# Altium schematic sheet Power.SchDoc
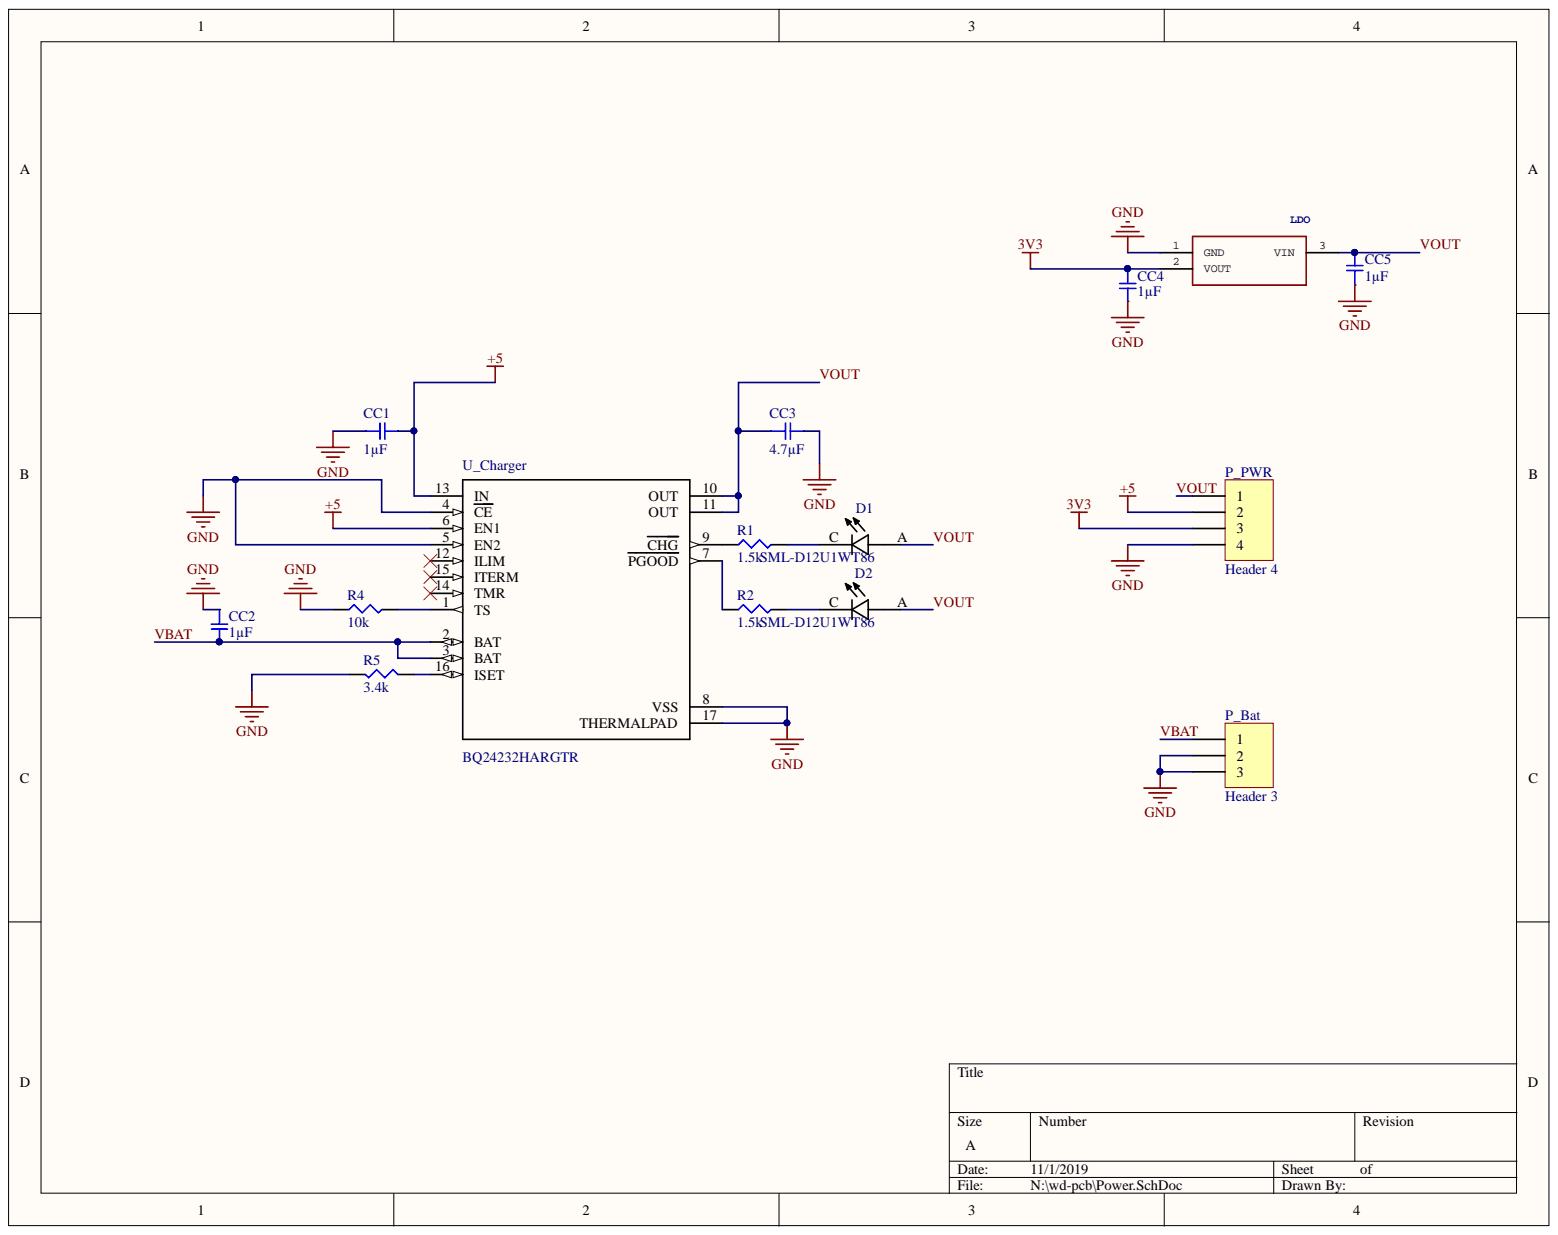
[diagram p0: Power.SchDoc]

## Parts
CC1  1µF  [SMD-0603C]
CC2  1µF  [SMD-0603C]
CC3  4.7µF  [SMD-0603C]
CC4  1µF  [SMD-0603C]
CC5  1µF  [SMD-0603C]
D1  SML-D12U1WT86  [*LED_SML-D12Y1WT86_SML-D12U1WT86(Primary)]
D2  SML-D12U1WT86  [*LED_SML-D12Y1WT86_SML-D12U1WT86(Primary)]
LDO  250mA 3.3V Ultra Low IQ LDO Reg. SOT23 DiodesZetex AP2138N-3.3TRG1, Low Noise LDO Voltage Regulator, 250 (Min)mA, 3.3 V +/-2%, 2.5 to 6.6 Vin, 3-Pin SOT-23Source:  Datasheet  [SOT95P282X145-3N]  (value not drawn)
P_Bat  Header 3  [HDR1X3]
P_PWR  Header 4  [HDR1X4]
R1  1.5k  [SMD-0603-RES]
R2  1.5k  [SMD-0603-RES]
R4  10k  [SMD-0603-RES]
R5  3.4k  [SMD-0603-RES]
U_Charger  BQ24232HARGTR  [*QFN50P300X300X100-17N]

## Nets
3V3: CC4.1 LDO.2 P_PWR.3
+5: CC1.2 P_PWR.2 U_Charger.6(EN1) U_Charger.13(IN)
GND: CC1.1 CC2.1 CC3.2 CC4.2 CC5.1 LDO.1 P_Bat.2 P_Bat.3 P_PWR.4 R4.1 R5.2 U_Charger.4(CE) U_Charger.5(EN2) U_Charger.8(VSS) U_Charger.17(THERMALPAD)
VBAT: CC2.2 P_Bat.1 U_Charger.2(BAT) U_Charger.3(BAT)
VOUT: CC3.1 CC5.2 D1.A D2.A LDO.3 P_PWR.1 U_Charger.10(OUT) U_Charger.11(OUT)
NetD1_C: D1.C R1.2
NetD2_C: D2.C R2.2
NetR1_1: R1.1 U_Charger.9(CHG)
NetR2_1: R2.1 U_Charger.7(PGOOD)
NetR4_2: R4.2 U_Charger.1(TS)
NetR5_1: R5.1 U_Charger.16(ISET)

Not connected: U_Charger 12(ILIM) 14(TMR) 15(ITERM)

## Library data of the parts
CC1 CC2 CC4 CC5 = GRM188R61A105KA61D: CAP CER 1UF 10V X5R 0603; Manufacturer: Murata Electronics North America; @ qty: 0; Minimum Quantity: 1; Tolerance: ±10%; Unit Price (USD): 0.1; Applications: General Purpose; Component Kind: Standard; Datasheets: http://www.murata.com/~/media/webrenewal/support/library/catalog/products/capacitor/mlcc/c02e.pdf; Digi-Key Part Number: 490-1543-1-ND; Factory Stock: 0; Features: -; Height - Seated (Max): -; Image: //media.digikey.com/Renders/Murata%20Renders/ZRB-SERIES-0402.jpg; Lead Spacing: -; Lead Style: -; Library Name: capacitor_murata_0603.IntLib; Mounting Type: Surface Mount, MLCC; Operating Temperature: -55°C ~ 85°C; Package / Case: 0603 (1608 Metric); Packaging: Cut Tape (CT); Part Number: GRM188R61A105KA61D; Part Status: Active; Quantity Available: 2638296; Ratings: -; Series: GRM; Size / Dimension: 0.063" L x 0.031" W (1.60mm x 0.80mm); Temperature Coefficient: X5R; Thickness (Max): 0.035" (0.90mm); Value: 1µF; Voltage: 10V
CC3 = GRM185R60G475ME15D: CAP CER 4.7UF 4V X5R 0603; Manufacturer: Murata Electronics North America; @ qty: 0; Minimum Quantity: 1; Tolerance: ±20%; Unit Price (USD): 0.25; Applications: General Purpose; Component Kind: Standard; Datasheets: http://www.murata.com/~/media/webrenewal/support/library/catalog/products/capacitor/mlcc/c02e.pdf; Digi-Key Part Number: 490-3891-1-ND; Factory Stock: 0; Features: -; Height - Seated (Max): -; Image: //media.digikey.com/Renders/Murata%20Renders/ZRB-SERIES-0402.jpg; Lead Spacing: -; Lead Style: -; Library Name: capacitor_murata_0603.IntLib; Mounting Type: Surface Mount, MLCC; Operating Temperature: -55°C ~ 85°C; Package / Case: 0603 (1608 Metric); Packaging: Cut Tape (CT); Part Number: GRM185R60G475ME15D; Part Status: Active; Quantity Available: 29936; Ratings: -; Series: GRM; Size / Dimension: 0.063" L x 0.031" W (1.60mm x 0.80mm); Temperature Coefficient: X5R; Thickness (Max): 0.020" (0.50mm); Value: 4.7µF; Voltage: 4V
D1 D2 = SML-D12U1WT86: SML-D12 Series Red 0603 Diffused 63 mcd 2.2 V Surface Mount LED; MF: Rohm; Component Kind: Standard; Digi-Key_Part_Number: SML-D12U1WT86CT-ND; Digi-Key_Purchase_URL: https://www.digikey.com/product-detail/en/rohm-semiconductor/SML-D12U1WT86/SML-D12U1WT86CT-ND/5843858?utm_source=snapeda&utm_medium=aggregator&utm_campaign=symbol; Library Name: SML-D12U1WT86.IntLib; MP: SML-D12U1WT86; Package: 0603 Rohm; SnapEDA_Link: https://www.snapeda.com/parts/SML-D12U1WT86/Rohm/view-part/?ref=snap
LDO = AP2138N-3.3TRG1: Manufacturer_Name: Diodes Inc.; Allied_Number: 70551004; GateName_1: G$1; Allied_Price-Stock: https://www.alliedelec.com/diodesinc-ap2138n-3-3trg1/70551004/; Component Kind: Standard; DeviceSetName: AP2138N-3.3TRG1; Height: 1.45mm; Library Name: AP2138N-3.3TRG1.IntLib; LibraryName: AP2138N-3.3TRG1; Manufacturer_Part_Number: AP2138N-3.3TRG1; Mouser_Part_Number: 621-AP2138N-3.3TRG1; Mouser_Price-Stock: https://www.mouser.com/Search/Refine.aspx?Keyword=621-AP2138N-3.3TRG1; Package: SOT95P282X145-3N; RS_Part_Number: 9210398P; RS_Price-Stock: http://uk.rs-online.com/web/p/products/9210398P; SymbolName_1: AP2138N-3.3TRG1
P_Bat = Header 3: Header, 3-Pin; Component Kind: Standard; LatestRevisionDate: 17-Jul-2002; LatestRevisionNote: Re-released for DXP Platform.; Library Name: Miscellaneous Connectors.IntLib; Signal Integrity: Connector; Publisher: Altium Limited
P_PWR = Header 4: Header, 4-Pin; Component Kind: Standard; LatestRevisionDate: 17-Jul-2002; LatestRevisionNote: Re-released for DXP Platform.; Library Name: Miscellaneous Connectors.IntLib; Signal Integrity: Connector; Publisher: Altium Limited
R1 R2 = ERJ-3EKF1501V: RES SMD 1.5K OHM 1% 1/10W 0603; Manufacturer: Panasonic Electronic Components; @ qty: 1500; Factory Stock: 0; Minimum Quantity: 1; Power (Watts): 0.1W, 1/10W; Temperature Coefficient: ±100ppm/°C; Unit Price (USD): 0.00379; Component Kind: Standard; Composition: Thick Film; Datasheets: http://industrial.panasonic.com/www-cgi/jvcr13pz.cgi?E+PZ+3+AOA0002+ERJ3EKF1501V+7+WW; Digi-Key Part Number: P1.50KHCT-ND; Failure Rate: -; Features: Automotive AEC-Q200; Height - Seated (Max): 0.022" (0.55mm); Image: //media.digikey.com/Photos/Panasonic%20Photos/MFG_ERJ-P6W%20Series.jpg; Library Name: Panasonic_ERJ_0603.IntLib; Number of Terminations: 2; Operating Temperature: -55°C ~ 155°C; Package / Case: 0603 (1608 Metric); Packaging: Cut Tape (CT); Part Number: ERJ-3EKF1501V; Part Status: Active; Quantity Available: 417970; Series: ERJ; Size / Dimension: 0.063" L x 0.031" W (1.60mm x 0.80mm); Supplier Device Package: 0603; Tolerance: ±1%; Value: 1.5k
R4 = ERJ-PA3J103V: RES SMD 10K OHM 5% 1/4W 0603; Manufacturer: Panasonic Electronic Components; @ qty: 1500; Factory Stock: 0; Minimum Quantity: 1; Power (Watts): 0.25W, 1/4W; Temperature Coefficient: ±200ppm/°C; Unit Price (USD): 0.01646; Component Kind: Standard; Composition: Thick Film; Datasheets: https://industrial.panasonic.com/cdbs/www-data/pdf/RDA0000/AOA0000C243.pdf; Digi-Key Part Number: P10KBZCT-ND; Failure Rate: -; Features: Automotive AEC-Q200, Pulse Withstanding; Height - Seated (Max): 0.022" (0.55mm); Image: //media.digikey.com/Photos/Panasonic%20Photos/MFG_ERJ-P6W%20Series.jpg; Library Name: Panasonic_ERJ_0603.IntLib; Number of Terminations: 2; Operating Temperature: -55°C ~ 155°C; Package / Case: 0603 (1608 Metric); Packaging: Cut Tape (CT); Part Number: ERJ-PA3J103V; Part Status: Active; Quantity Available: 26345; Series: ERJ; Size / Dimension: 0.063" L x 0.031" W (1.60mm x 0.80mm); Supplier Device Package: 0603; Tolerance: ±5%; Value: 10k
R5 = ERJ-3EKF3401V: RES SMD 3.4K OHM 1% 1/10W 0603; Manufacturer: Panasonic Electronic Components; @ qty: 1500; Factory Stock: 0; Minimum Quantity: 1; Power (Watts): 0.1W, 1/10W; Temperature Coefficient: ±100ppm/°C; Unit Price (USD): 0.00379; Component Kind: Standard; Composition: Thick Film; Datasheets: http://industrial.panasonic.com/www-cgi/jvcr13pz.cgi?E+PZ+3+AOA0002+ERJ3EKF3401V+7+WW; Digi-Key Part Number: P3.40KHCT-ND; Failure Rate: -; Features: Automotive AEC-Q200; Height - Seated (Max): 0.022" (0.55mm); Image: //media.digikey.com/Photos/Panasonic%20Photos/MFG_ERJ-P6W%20Series.jpg; Library Name: Panasonic_ERJ_0603.IntLib; Number of Terminations: 2; Operating Temperature: -55°C ~ 155°C; Package / Case: 0603 (1608 Metric); Packaging: Cut Tape (CT); Part Number: ERJ-3EKF3401V; Part Status: Active; Quantity Available: 58954; Series: ERJ; Size / Dimension: 0.063" L x 0.031" W (1.60mm x 0.80mm); Supplier Device Package: 0603; Tolerance: ±1%; Value: 3.4k
U_Charger = BQ24232HARGTR: USB-Friendly Lithium-Ion Battery Charger and Power-Path Management IC 0.5A 10.5V OVP 16-Pin QFN T/R; MF: Texas Instruments; Component Kind: Standard; Digi-Key_Part_Number: 296-49554-1-ND; Digi-Key_Purchase_URL: https://www.digikey.com/product-detail/en/texas-instruments/BQ24232HARGTR/296-49554-1-ND/9462552?utm_source=snapeda&utm_medium=aggregator&utm_campaign=symbol; Library Name: BQ24232HARGTR.IntLib; MP: BQ24232HARGTR; Package: VFQFN-16 Texas Instruments; SnapEDA_Link: https://www.snapeda.com/parts/BQ24232HARGTR/Texas%20Instruments/view-part/?ref=snap
